# Altium schematic sheet main.SchDoc(main)
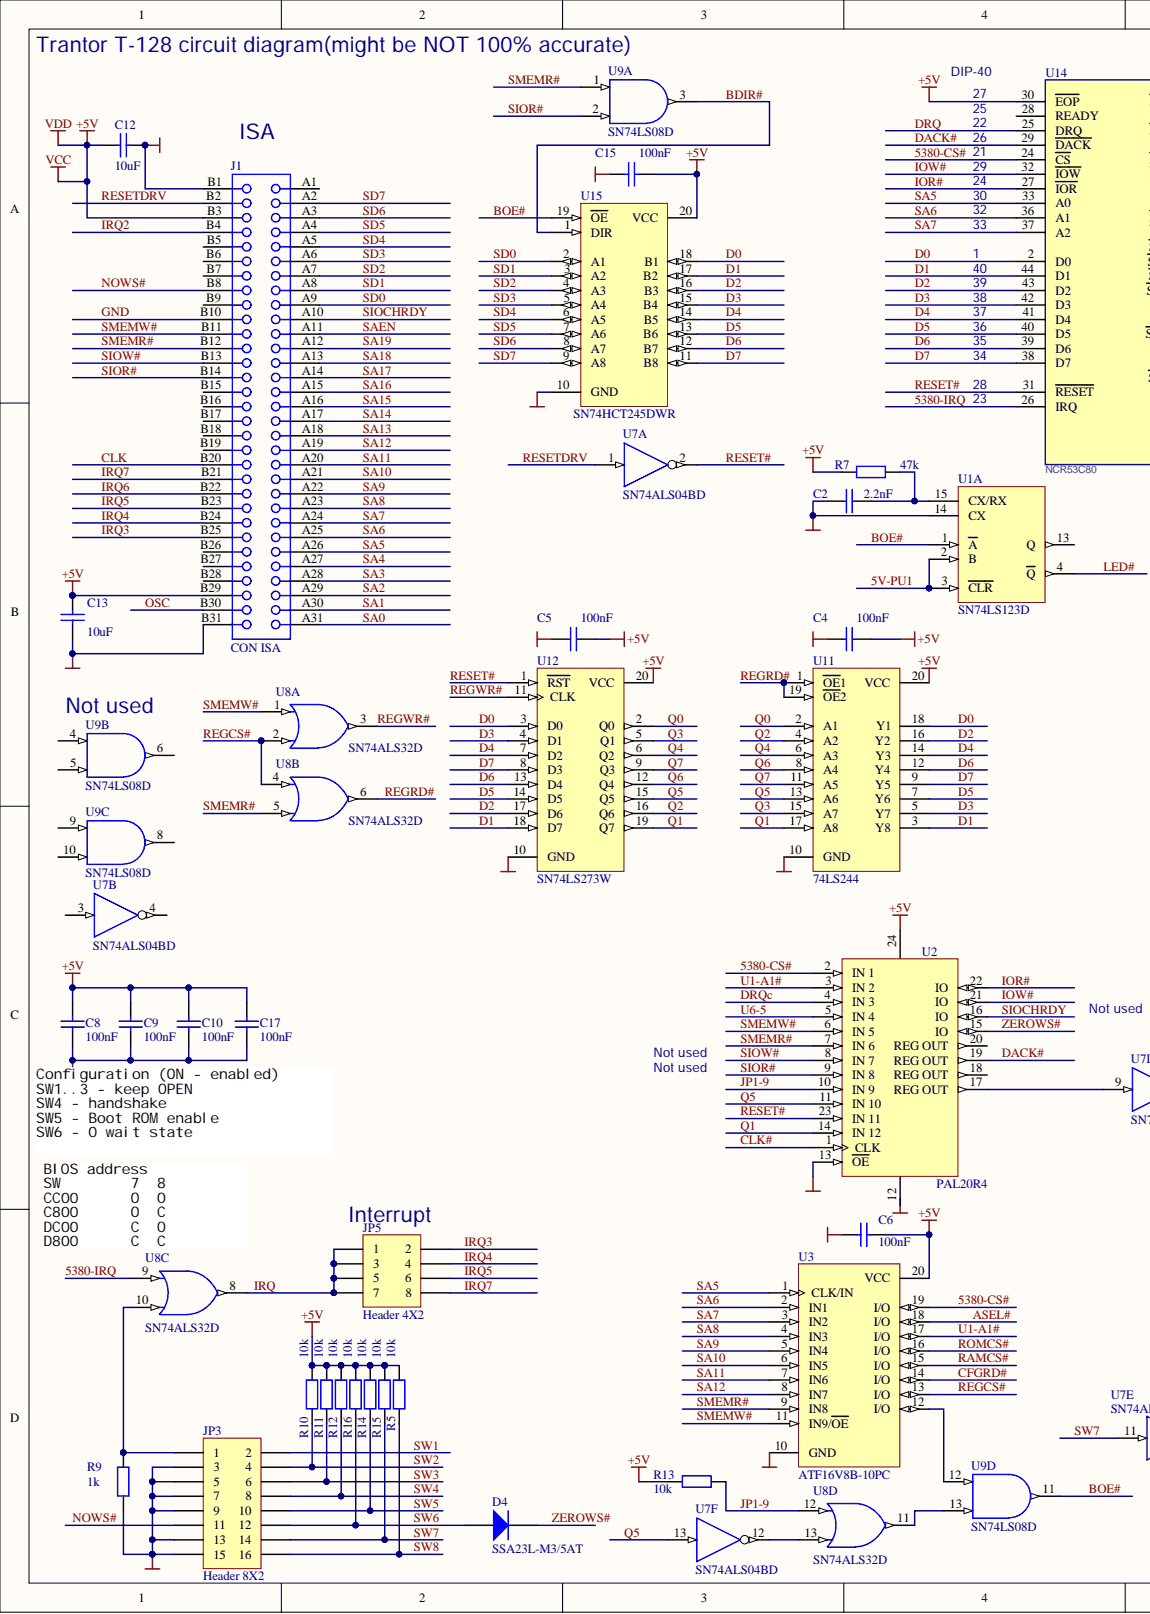
[diagram p0: main.SchDoc, left]
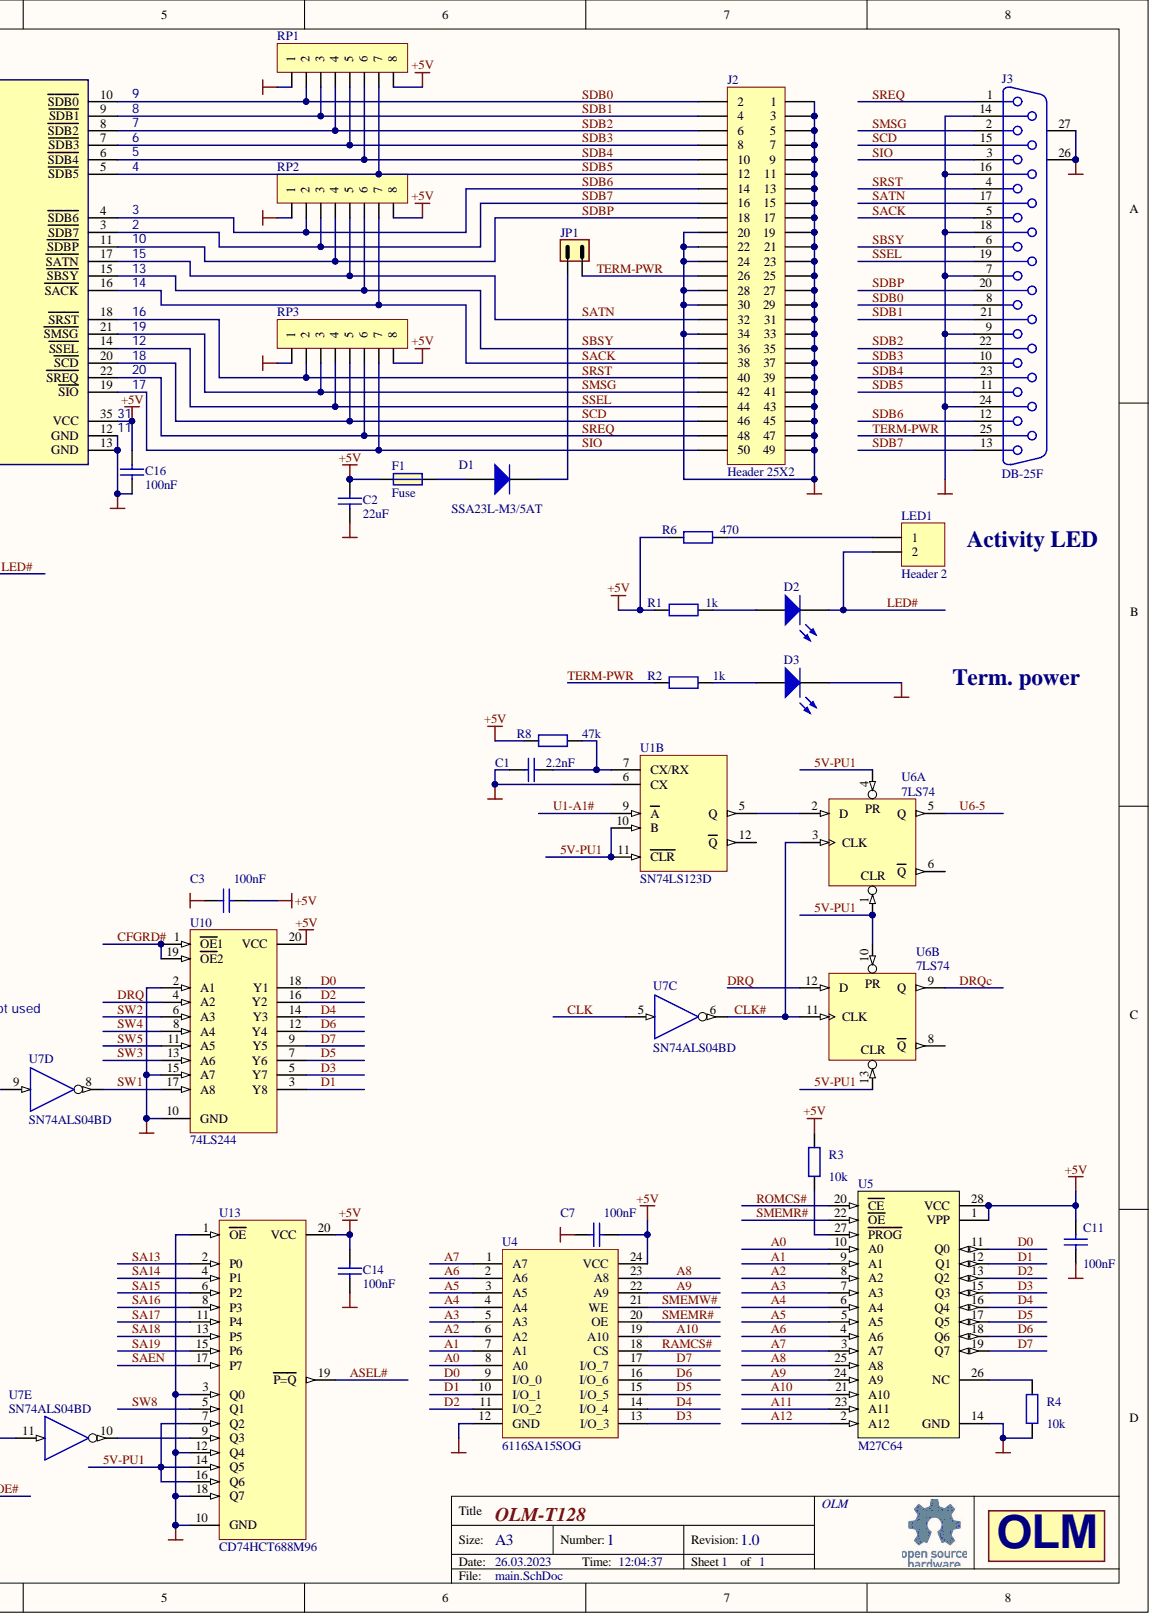
[diagram p1: main.SchDoc, right]

## Parts
A1  OSHW  [OSHW-LOGO-10MM]  (value not drawn)
C1  value 2.2nF  [SM/C_0805]  (hidden comment: Capacitor)
C2  value 22uF  [SM/C_1206]  (hidden comment: Cap)
C2  value 2.2nF  [SM/C_0805]  (hidden comment: Capacitor)
C3  value 100nF  [SM/C_0805]  (hidden comment: Capacitor)
C4  value 100nF  [SM/C_0805]  (hidden comment: Capacitor)
C5  value 100nF  [SM/C_0805]  (hidden comment: Capacitor)
C6  value 100nF  [SM/C_0805]  (hidden comment: Capacitor)
C7  value 100nF  [SM/C_0805]  (hidden comment: Capacitor)
C8  value 100nF  [SM/C_0805]  (hidden comment: Capacitor)
C9  value 100nF  [SM/C_0805]  (hidden comment: Capacitor)
C10  value 100nF  [SM/C_0805]  (hidden comment: Capacitor)
C11  value 100nF  [SM/C_0805]  (hidden comment: Capacitor)
C12  value 10uF  [SM/C_1206]  (hidden comment: Capacitor)
C13  value 10uF  [SM/C_1206]  (hidden comment: Capacitor)
C14  value 100nF  [SM/C_0805]  (hidden comment: Capacitor)
C15  value 100nF  [SM/C_0805]  (hidden comment: Capacitor)
C16  value 100nF  [SM/C_0805]  (hidden comment: Capacitor)
C17  value 100nF  [SM/C_0805]  (hidden comment: Capacitor)
D1  value SSA23L-M3/5AT  Part #: SSA23L-M3/5AT  [SM/DO214AC_21]  (hidden comment: Diode 1N4448)
D2  LED  [SM/LED_1206_Red]  (value not drawn)
D3  LED SMD  [SM/LED_1206_Green]  (value not drawn)
D4  value SSA23L-M3/5AT  Part #: SSA23L-M3/5AT  [SM/DO214AC_21]  (hidden comment: Diode 1N4448)
F1  Fuse  [Fuse_PTC_1812]
J1  CON ISA  [ISA/FINGERS_ISA_8BIT]  (no BOM)
J2  Header 25X2  [WALCON.100/VH/TM2OE/W.325/50]
J3  DB-25F  [DSUB DB-25F]
JP1  Jumper  [pls-2]  (value not drawn)
JP3  Header 8X2  [PLD-16]
JP5  Header 4X2  [PLD-8]
LED1  Header 2  [pls-2]
R1  value 1k  [SM/R_0805]  (hidden comment: Resistor)
R2  value 1k  [SM/R_0805]  (hidden comment: Resistor)
R3  value 10k  [SM/R_0805]  (hidden comment: Resistor)
R4  value 10k  [SM/R_0805]  (hidden comment: Resistor)
R5  value 10k  [SM/R_0805]  (hidden comment: Resistor)
R6  value 470  [SM/R_0805]  (hidden comment: Resistor)
R7  value 47k  [SM/R_0805]  (hidden comment: Resistor)
R8  value 47k  [SM/R_0805]  (hidden comment: Resistor)
R9  value 1k  [SM/R_0805]  (hidden comment: Resistor)
R10  value 10k  [SM/R_0805]  (hidden comment: Resistor)
R11  value 10k  [SM/R_0805]  (hidden comment: Resistor)
R12  value 10k  [SM/R_0805]  (hidden comment: Resistor)
R13  value 10k  [SM/R_0805]  (hidden comment: Resistor)
R14  value 10k  [SM/R_0805]  (hidden comment: Resistor)
R15  value 10k  [SM/R_0805]  (hidden comment: Resistor)
R16  value 10k  [SM/R_0805]  (hidden comment: Resistor)
RP1  Header 8  [SIP-8]  (value not drawn)
RP2  Header 8  [SIP-8]  (value not drawn)
RP3  Header 8  [SIP-8]  (value not drawn)
U1  SN74LS123D  [751B-05_N]  (parts A,B)
U2  PAL20R4  [DIP-24/X1.5]
U3  ATF16V8B-10PC  [PLCC20_SOCKET]
U4  6116SA15SOG  [SOG.050/24/WG.420/L.625]
U5  M27C64  [Socket DIP28]
U6  7LS74  [D014_N]  (parts A,B)
U7  SN74ALS04BD  [D014_N]  (parts A,B,C,D,E,F)
U8  SN74ALS32D  [D014_N]  (parts A,B,C,D)
U9  SN74LS08D  [751A-03_N]  (parts A,B,C,D)
U10  74LS244  [J020]
U11  74LS244  [J020]
U12  SN74LS273W  [W020]
U13  74HCT688  value CD74HCT688M96  Part #: CD74HCT688M96  [SOG.050/20/WG.420/L.500]
U14  NCR53C80  [PLCC44/SMS/.875]
U15  74HCT245  value SN74HCT245DWR  Part #: SN74HCT245DWR  [SOG.050/20/WG.420/L.500]

## Nets
+5V: C2.1 C3.1 C4.1 C5.1 C6.1 C7.1 C8.1 C9.1 C10.1 C11.1 C12.2 C13.2 C14.1 C15.1 C16.1 C17.1 F1.1 J1.B3 J1.B29 R1.2 R3.1 R5.1 R6.2 R7.2 R8.2 R10.1 R11.1 R12.1 R13.2 R14.1 R15.1 R16.1 RP1.8 RP2.8 RP3.8 U1.16 U2.24(VCC) U3.20(VCC) U4.24(VCC) U5.1(VPP) U5.28(VCC) U6.14 U7.14 U8.14 U9.14 U10.20(VCC) U11.20(VCC) U12.20(VCC) U13.20(VCC) U14.30(EOP) U14.35(VCC) U15.20(VCC)
5V-PU1: U1.2(B) U1.3(CLR) U1.10(B) U1.11(CLR) U6.1 U6.4(PR) U6.10(PR) U6.13 U13.7(Q2) U13.14(Q5) U13.16(Q6)
5380-CS#: U2.2(IN_1) U3.19(I/O) U14.24(CS)
5380-IRQ: U8.9 U14.26(IRQ)
A0: U4.8(A0) U5.10(A0)
A1: U4.7(A1) U5.9(A1)
A2: U4.6(A2) U5.8(A2)
A3: U4.5(A3) U5.7(A3)
A4: U4.4(A4) U5.6(A4)
A5: U4.3(A5) U5.5(A5)
A6: U4.2(A6) U5.4(A6)
A7: U4.1(A7) U5.3(A7)
A8: U4.23(A8) U5.25(A8)
A9: U4.22(A9) U5.24(A9)
A10: U4.19(A10) U5.21(A10)
A11: U5.23(A11)
A12: U5.2(A12)
ASEL#: U3.18(I/O) U13.19(P=Q)
BDIR#: U9.3 U15.1(DIR)
BOE#: U1.1(A) U9.11 U15.19(OE)
CFGRD#: U3.14(I/O) U10.1(OE1) U10.19(OE2)
CLK: J1.B20 U7.5
CLK#: U2.1(CLK) U6.3(CLK) U6.11(CLK) U7.6
D0: U4.9(I/O_0) U5.11(Q0) U10.18(Y1) U11.18(Y1) U12.3(D0) U14.2(D0) U15.18(B1)
D1: U4.10(I/O_1) U5.12(Q1) U10.3(Y8) U11.3(Y8) U12.18(D7) U14.44(D1) U15.17(B2)
D2: U4.11(I/O_2) U5.13(Q2) U10.16(Y2) U11.16(Y2) U12.17(D6) U14.43(D2) U15.16(B3)
D3: U4.13(I/O_3) U5.15(Q3) U10.5(Y7) U11.5(Y7) U12.4(D1) U14.42(D3) U15.15(B4)
D4: U4.14(I/O_4) U5.16(Q4) U10.14(Y3) U11.14(Y3) U12.7(D2) U14.41(D4) U15.14(B5)
D5: U4.15(I/O_5) U5.17(Q5) U10.7(Y6) U11.7(Y6) U12.14(D5) U14.40(D5) U15.13(B6)
D6: U4.16(I/O_6) U5.18(Q6) U10.12(Y4) U11.12(Y4) U12.13(D4) U14.39(D6) U15.12(B7)
D7: U4.17(I/O_7) U5.19(Q7) U10.9(Y5) U11.9(Y5) U12.8(D3) U14.38(D7) U15.11(B8)
DACK#: U2.19(REG_OUT) U14.29(DACK)
DRQ: U6.12(D) U10.4(A2) U14.25(DRQ)
DRQC: U2.4(IN_3) U6.9(Q)
GND: C1.2 C2.2 C3.2 C4.2 C5.2 C6.2 C7.2 C8.2 C9.2 C10.2 C11.2 C12.1 C13.1 C14.2 C15.2 C16.2 C17.2 D3.2 J1.B1 J1.B10 J1.B31 J2.1 J2.3 J2.5 J2.7 J2.9 J2.11 J2.13 J2.15 J2.17 J2.19 J2.20 J2.21 J2.22 J2.23 J2.24 J2.25 J2.27 J2.28 J2.29 J2.30 J2.31 J2.33 J2.34 J2.35 J2.37 J2.39 J2.41 J2.43 J2.45 J2.47 J2.49 J3.7 J3.9 J3.14 J3.16 J3.18 J3.24 J3.26 J3.27 JP3.3 JP3.5 JP3.7 JP3.9 JP3.13 JP3.15 R4.2 R9.2 RP1.1 RP2.1 RP3.1 U1.6(CX) U1.8 U1.14(CX) U2.12(GND) U2.13(OE) U3.10(GND) U4.12(GND) U5.14(GND) U6.7 U7.7 U8.7 U9.7 U10.2(A1) U10.10(GND) U10.15(A7) U11.10(GND) U12.10(GND) U13.1(OE) U13.3(Q0) U13.10(GND) U13.12(Q4) U13.18(Q7) U14.12(GND) U14.13(GND) U15.10(GND)
IOR#: U2.22(IO) U14.27(IOR)
IOW#: U2.21(IO) U14.32(IOW)
IRQ: JP5.1 JP5.3 JP5.5 JP5.7 U8.8
IRQ2: J1.B4
IRQ3: J1.B25 JP5.2
IRQ4: J1.B24 JP5.4
IRQ5: J1.B23 JP5.6
IRQ6: J1.B22
IRQ7: J1.B21 JP5.8
JP1-9: R13.1 U2.10(IN_9) U8.12
LED#: D2.2 LED1.2 U1.4(Q)
NOWS#: J1.B8 JP3.11
OSC: J1.B30
Q0: U11.2(A1) U12.2(Q0)
Q1: U2.14(IN_12) U11.17(A8) U12.19(Q7)
Q2: U11.4(A2) U12.16(Q6)
Q3: U11.15(A7) U12.5(Q1)
Q4: U11.6(A3) U12.6(Q2)
Q5: U2.11(IN_10) U7.13 U11.13(A6) U12.15(Q5)
Q6: U11.8(A4) U12.12(Q4)
Q7: U11.11(A5) U12.9(Q3)
RAMCS#: U3.15(I/O) U4.18(CS)
REGCS#: U3.13(I/O) U8.2 U8.4
REGRD#: U8.6 U11.1(OE1) U11.19(OE2)
REGWR#: U8.3 U12.11(CLK)
RESET#: U2.23(IN_11) U7.2 U12.1(RST) U14.31(RESET)
RESETDRV: J1.B2 U7.1
ROMCS#: U3.16(I/O) U5.20(CE)
SA0: J1.A31
SA1: J1.A30
SA2: J1.A29
SA3: J1.A28
SA4: J1.A27
SA5: J1.A26 U3.1(CLK/IN) U14.33(A0)
SA6: J1.A25 U3.2(IN1) U14.36(A1)
SA7: J1.A24 U3.3(IN2) U14.37(A2)
SA8: J1.A23 U3.4(IN3)
SA9: J1.A22 U3.5(IN4)
SA10: J1.A21 U3.6(IN5)
SA11: J1.A20 U3.7(IN6)
SA12: J1.A19 U3.8(IN7)
SA13: J1.A18 U13.2(P0)
SA14: J1.A17 U13.4(P1)
SA15: J1.A16 U13.6(P2)
SA16: J1.A15 U13.8(P3)
SA17: J1.A14 U13.11(P4)
SA18: J1.A13 U13.13(P5)
SA19: J1.A12 U13.15(P6)
SACK: J2.38 J3.5 RP2.7 U14.16(SACK)
SAEN: J1.A11 U13.17(P7)
SATN: J2.32 J3.17 RP2.5 U14.17(SATN)
SBSY: J2.36 J3.6 RP2.6 U14.15(SBSY)
SCD: J2.46 J3.15 RP3.5 U14.20(SCD)
SD0: J1.A9 U15.2(A1)
SD1: J1.A8 U15.3(A2)
SD2: J1.A7 U15.4(A3)
SD3: J1.A6 U15.5(A4)
SD4: J1.A5 U15.6(A5)
SD5: J1.A4 U15.7(A6)
SD6: J1.A3 U15.8(A7)
SD7: J1.A2 U15.9(A8)
SDB0: J2.2 J3.8 RP1.2 U14.10(SDB0)
SDB1: J2.4 J3.21 RP1.3 U14.9(SDB1)
SDB2: J2.6 J3.22 RP1.4 U14.8(SDB2)
SDB3: J2.8 J3.10 RP1.5 U14.7(SDB3)
SDB4: J2.10 J3.23 RP1.6 U14.6(SDB4)
SDB5: J2.12 J3.11 RP1.7 U14.5(SDB5)
SDB6: J2.14 J3.12 RP2.2 U14.4(SDB6)
SDB7: J2.16 J3.13 RP2.3 U14.3(SDB7)
SDBP: J2.18 J3.20 RP2.4 U14.11(SDBP)
SIO: J2.50 J3.3 RP3.7 U14.19(SIO)
SIOCHRDY: J1.A10 U2.16(IO)
SIOR#: J1.B14 U2.9(IN_8) U9.2
SIOW#: J1.B13 U2.8(IN_7)
SMEMR#: J1.B12 U2.7(IN_6) U3.9(IN8) U4.20(OE) U5.22(OE) U8.5 U9.1
SMEMW#: J1.B11 U2.6(IN_5) U3.11(IN9/OE) U4.21(WE) U8.1
SMSG: J2.42 J3.2 RP3.3 U14.21(SMSG)
SREQ: J2.48 J3.1 RP3.6 U14.22(SREQ)
SRST: J2.40 J3.4 RP3.2 U14.18(SRST)
SSEL: J2.44 J3.19 RP3.4 U14.14(SSEL)
SW1: JP3.2 U7.8 U10.17(A8)
SW2: JP3.4 R10.2 U10.6(A3)
SW3: JP3.6 R11.2 U10.13(A6)
SW4: JP3.8 R12.2 U10.8(A4)
SW5: JP3.10 R14.2 U10.11(A5)
SW6: D4.1 JP3.12 R16.2
SW7: JP3.14 R15.2 U7.11
SW8: JP3.16 R5.2 U13.5(Q1)
TERM-PWR: J2.26 J3.25 JP1.1 R2.2
U1-A1#: U1.9(A) U2.3(IN_2) U3.17(I/O)
U6-5: U2.5(IN_4) U6.5(Q)
ZEROWS#: D4.2 U2.15(IO)
NetC1_1: C1.1 R8.1 U1.7(CX/RX)
NetC2_1: C2.1 R7.1 U1.15(CX/RX)
NetD1_1: D1.1 F1.2
NetD1_2: D1.2 JP1.2
NetD2_1: D2.1 R1.1
NetD3_1: D3.1 R2.1
NetJP3_1: JP3.1 R9.1 U8.10
NetLED1_1: LED1.1 R6.1
NetR3_2: R3.2 U5.27(PROG)
NetR4_1: R4.1 U5.26(NC)
NetU1_5: U1.5(Q) U6.2(D)
NetU2_17: U2.17(REG_OUT) U7.9
NetU3_12: U3.12(I/O) U9.12
NetU7_10: U7.10 U13.9(Q3)
NetU7_12: U7.12 U8.13
NetU8_11: U8.11 U9.13

Not connected: J1 A1 B5 B6 B7 B9 B15 B16 B17 B18 B19 B26 B27 B28; U1 12(Q) 13(Q); U2 18(REG_OUT) 20(REG_OUT); U6 6(Q) 8(Q); U7 3 4; U9 4 5 6 8 9 10; U14 28(READY)

## Library data of the parts
C1-C11 C14-C17 = Cap ceramic: Ceramic capacitor SMD; Manufacturer: Vishay Intertech; Part #: K104K15X7RF5TH5; Part footprint: 0805; Component Kind: Standard; LCSC Part#: C2167231; Library Name: New.SCHLIB
C2 = Cap ceramic: CAP-100Nf; Component Kind: Standard; Library Name: New.SCHLIB
C12 C13 = Cap ceramic: Ceramic capacitor SMD; Manufacturer: Dersonic; Part #: CD1H106KC9IER2F100; Part footprint: 1206; Component Kind: Standard; LCSC Part#: C2761740; Library Name: New.SCHLIB
D1 D4 = Diode 1N4448: High Conductance Fast Diode; Code_JEDEC: DO-204-AH; Component Kind: Standard; ComponentLink1Description: Manufacturer Link; ComponentLink1URL: http://www.fairchildsemi.com/; ComponentLink2Description: Datasheet; ComponentLink2URL: http://www.fairchildsemi.com/ds/1N%2F1N4448.pdf; DatasheetVersion: 1997; LatestRevisionDate: 17-Jul-2002; LatestRevisionNote: Re-released for DXP Platform.; Library Name: Miscellaneous Devices.IntLib; PackageDescription: Diode, Axial; Body 3.8 x 1.9 mm (LxDia. max), Lead Dia. 0.53 mm (max); PackageReference: DO-35; Published: 8-Jun-2000; Signal Integrity: 1N4448; Simulation: 1N4448; Publisher: Altium Limited
D2 = LED0: LED SMD 1206 Red; Manufacturer: Everlight Elec; Part #: 15-21/R6C-FQ1R1B/2T; Part footprint: 1206; Value: 15-21/R6C-FQ1R1B/2T; Component Kind: Standard; LatestRevisionDate: 08-Jul-2005; LatestRevisionNote: Mech Layer 1 removed.; LCSC Part#: C93133; Library Name: Miscellaneous Devices.IntLib; PackageDescription: LED; 2 Leads; PackageReference: LED-0; Published: 8-Jun-2000; Simulation: LED0; Publisher: Altium Limited
D3 = LED0: LED SMD 1206 Green; Manufacturer: Everlight Elec; Part #: 24-21/G6C-BM1N2L/2A; Part footprint: 1206; Value: 24-21/G6C-BM1N2L/2A; Component Kind: Standard; LatestRevisionDate: 08-Jul-2005; LatestRevisionNote: Mech Layer 1 removed.; LCSC Part#: C2980185; Library Name: Miscellaneous Devices.IntLib; PackageDescription: LED; 2 Leads; PackageReference: LED-0; Published: 8-Jun-2000; Simulation: LED0; Publisher: Altium Limited
F1 = Fuse_SMD: Component Kind: Standard; Library Name: New_home.SCHLIB
J1 = CON ISA: ISA connecotr; ContactPlating: Gold Flash; DrillSize: Corresponds to nominal value.; Note: Access Keys, E6 E16 E25 F6 F16 F25 G6 G15 H6 H15 not shown.; PCB_Layout: Complies with manufacturer's recommendation.; ComponentLink1Description: Manufacturer Link; ComponentLink1URL: http://www.astron.com.tw; DatasheetDocument: Feb-1997; LatestRevisionDate: 15-Jul-2004; LatestRevisionNote: Component Replaced.; Library Name: Connectors_home.SchLib; PackageDocument: Feb-1997; PackageReference: EISA188; Published: 15-Jul-2004; Publisher: Altium Limited
J2 = Header 25X2: Header, 25-Pin, Dual row; Component Kind: Standard; LatestRevisionDate: 17-Jul-2002; LatestRevisionNote: Re-released for DXP Platform.; Library Name: Miscellaneous Connectors.IntLib; Signal Integrity: Connector; Publisher: Altium Limited
J3 = D Connector 25: Receptacle Assembly, 25 Position, Right Angle; PackageDocument: U; Positions: 25; Component Kind: Standard; DatasheetDocument: 19-Mar-1999; Gender: Receptacle; LatestRevisionDate: 17-Jul-2002; LatestRevisionNote: Re-released for DXP Platform.; Library Name: Miscellaneous Connectors.IntLib; Orientation: Right Angle; PackageReference: 787202; Published: 15-Jun-2001; Signal Integrity: Connector; Publisher: Altium Limited
JP1 = Jumper2: Jumper Wire; Manufacturer: Generic Components; Component Kind: Standard; Library Name: New_home.SCHLIB; Published: 1999; Value: Jumper
JP3 = Header 8X2: Header, 8-Pin, Dual row; Component Kind: Standard; LatestRevisionDate: 17-Jul-2002; LatestRevisionNote: Re-released for DXP Platform.; Library Name: Miscellaneous Connectors.IntLib; Signal Integrity: Connector; Publisher: Altium Limited
JP5 = Header 4X2: Header, 4-Pin, Dual row; Component Kind: Standard; LatestRevisionDate: 17-Jul-2002; LatestRevisionNote: Re-released for DXP Platform.; Library Name: Miscellaneous Connectors.IntLib; Signal Integrity: Connector; Publisher: Altium Limited
LED1 = Header 2: Header, 2-Pin; Component Kind: Standard; LatestRevisionDate: 17-Jul-2002; LatestRevisionNote: Re-released for DXP Platform.; Library Name: Miscellaneous Connectors.IntLib; Signal Integrity: Connector; Publisher: Altium Limited
R1 R2 R6-R9 = Res2: Manufacturer: Panasonic; Part #: ERJ6GEYJ102V; Part footprint: 0805; Component Kind: Standard; Description: Resistor; LatestRevisionDate: 17-Jul-2002; LatestRevisionNote: Re-released for DXP Platform.; LCSC Part#: C278655; Library Name: Miscellaneous Devices.IntLib; PackageReference: AXIAL-0.4; Published: 8-Jun-2000; Signal Integrity: Res; Simulation: RESISTOR; Publisher: Altium Limited
R3-R5 R10-R16 = Res2: Resistor SMD; Manufacturer: Panasonic; Part #: ERJ6GEYJ103V; Part footprint: 0805; Component Kind: Standard; LatestRevisionDate: 17-Jul-2002; LatestRevisionNote: Re-released for DXP Platform.; LCSC Part#: C180849; Library Name: Miscellaneous Devices.IntLib; PackageReference: AXIAL-0.4; Published: 8-Jun-2000; Signal Integrity: Res; Simulation: RESISTOR; Publisher: Altium Limited
RP1-RP3 = Header 8: Header, 8-Pin; Component Kind: Standard; LatestRevisionDate: 17-Jul-2002; LatestRevisionNote: Re-released for DXP Platform.; Library Name: New_home.SCHLIB; Signal Integrity: Connector; Publisher: Altium Limited
U1 = SN74LS123D: Retriggerable Monostable Multivibrator; Code_IPC: SOIC127P600-16; Code_JEDEC: MS-012-AC; Component Kind: Standard; ComponentLink1Description: Manufacturer Link; ComponentLink1URL: http://www.onsemi.com/; ComponentLink2Description: Datasheet; ComponentLink2URL: http://www.onsemi.com/pub/Collateral/SN74LS122-D.PDF; DatasheetVersion: Dec-1999; LatestRevisionDate: 13-Apr-2006; LatestRevisionNote: IPC-7351 Footprint Added.; Library Name: ON Semi Logic Multivibrator.IntLib; PackageDescription: 16-Pin Small Outline Integrated Circuit 1.27 mm Pitch; PackageReference: 751B-05; PackageVersion: Oct-1999; Published: 15-Apr-2002; Signal Integrity: SN74LS259N; Publisher: Altium Limited
U2 = p20r4: 7.5ns 24-Pin TTL PAL; Component Kind: Standard; LatestRevisionDate: 17-Jul-2002; LatestRevisionNote: Re-released for DXP Platform.; Published: 16-Jul-2002; Library Name: PLD Supported Devices.IntLib; Publisher: Altium Limited
U3 = ATF16V8B-10PC: ATF16V8B 5.0V Industry Standard SPLD, 20-lead PDIP, Speed Grade 10, Commercial Grade; Code_JEDEC: MS-001-AD; Component Kind: Standard; ComponentLink1Description: Manufacturer Link; ComponentLink1URL: http://www.atmel.com/products/pld/default.asp; ComponentLink2Description: Datasheet; ComponentLink2URL: http://www.atmel.com/dyn/resources/prod_documents/DOC0364.PDF; ComponentLink3Description: Atmel.com Home; ComponentLink3URL: http://www.atmel.com; DatasheetVersion: Rev. 0364I-04/01; LatestRevisionDate: 04-Mar-2005; LatestRevisionNote: Stylized 3D Model Added.; Library Name: Atmel Industry Standard SPLD.IntLib; PackageDescription: 20-lead (0.300"/7.62mm Wide) Plastic Dual Inline Package (PDIP); PackageReference: 20P3; PackageVersion: revC, Jan-2004; Published: 24-Nov-2000; Supplier 1: Digi-Key; Supplier 2: Mouser; Supplier Part Number 1: ATF16V8B-10PC-ND; Supplier Part Number 2: 556-ATF16V8B-10PC; Publisher: Altium Limited
U4 = 6116SA15SOG: 5.0V 2K x 8 Asynchronous Static RAM; Manufacturer_Name: Renesas Electronics; Geometry.Height: 2.1mm; Component Kind: Standard; Datasheet Link: https://www.renesas.com/us/en/products/memory-logic/srams/asynchronous-srams/6116-50v-2k-x-8-asynchronous-static-ram; Library Name: New_home.SCHLIB; Manufacturer_Part_Number: 6116SA15SOG; Mouser Part Number: 972-6116SA15SOG; Mouser Price/Stock: https://www.mouser.co.uk/ProductDetail/Renesas-IDT/6116SA15SOG?qs=GVScuG1d83jOy4N7V8POIA%3D%3D
U5 = M27C64A-15F1: 64 Kbit (8Kb X 8) UV EPROM and OTP EPROM; Component Kind: Standard; ComponentLink1Description: Manufacturer Link; ComponentLink1URL: http://www.st.com/; ComponentLink2Description: Datasheet; ComponentLink2URL: http://www.st.com/stonline/products/literature/ds/2388/m27c64a.pdf; DatasheetVersion: Mar-1998; LatestRevisionDate: 06-Jun-2005; LatestRevisionNote: Stylized 3D Model Added.; Library Name: ST Memory EPROM 16-512 Kbit.IntLib; PackageDescription: Ceramic Frit-seal DIP, Window; 28 Leads; Row Spacing 15.24 mm; Pitch 2.54 mm; PackageReference: FDIP28W; PCB3D: FDIP28W; Published: 24-Aug-2001; Publisher: Altium Limited
U6 = SN74AHCT74D: Dual Positive-Edge-Triggered D-Type Flip-Flop with Clear and Preset; Code_IPC: SOIC127P600-14; Component Kind: Standard; ComponentLink1Description: Manufacturer Link; ComponentLink1URL: http://www.ti.com/; ComponentLink2Description: Datasheet; ComponentLink2URL: http://www-s.ti.com/sc/ds/sn74ahct74.pdf; DatasheetVersion: Oct-1998; LatestRevisionDate: 13-Apr-2006; LatestRevisionNote: IPC-7351 Footprint Added.; Library Name: TI Logic Flip-Flop.IntLib; PackageDescription: 14-Pin Small Outline Integrated Circuit 1.27 mm Pitch; PackageReference: D014; PackageVersion: Jan-1998; PCB3D: D014; Published: 8-Jun-2000; Signal Integrity: SN74AHCT74D; Publisher: Altium Limited
U7 = SN74ALS04BD: Hex Inverter; Code_IPC: SOIC127P600-14; Component Kind: Standard; ComponentLink1Description: Manufacturer Link; ComponentLink1URL: http://www.ti.com/; ComponentLink2Description: Datasheet; ComponentLink2URL: http://www-s.ti.com/sc/ds/sn54as04.pdf; ComponentLink3Description: Packaging Specification; ComponentLink3URL: http://focus.ti.com/lit/ml/mpds177/mpds177.pdf; DatasheetVersion: Dec-1994; LatestRevisionDate: 13-Apr-2006; LatestRevisionNote: IPC-7351 Footprint Added.; Library Name: TI Logic Gate 1.IntLib; PackageDescription: 14-Pin Small Outline Integrated Circuit 1.27 mm Pitch; PackageReference: D014; PackageVersion: Jan-1998; PCB3D: D014; Published: 8-Jun-2000; Signal Integrity: SN74ALS04BD; Publisher: Altium Limited
U8 = SN74ALS32D: Quadruple 2-Input Positive-OR Gate; Code_IPC: SOIC127P600-14; Component Kind: Standard; ComponentLink1Description: Manufacturer Link; ComponentLink1URL: http://www.ti.com/; ComponentLink2Description: Datasheet; ComponentLink2URL: http://www-s.ti.com/sc/ds/sn74als32.pdf; ComponentLink3Description: Packaging Specification; ComponentLink3URL: http://focus.ti.com/lit/ml/mpds177/mpds177.pdf; DatasheetVersion: Dec-1994; LatestRevisionDate: 13-Apr-2006; LatestRevisionNote: IPC-7351 Footprint Added.; Library Name: TI Logic Gate 1.IntLib; PackageDescription: 14-Pin Small Outline Integrated Circuit 1.27 mm Pitch; PackageReference: D014; PackageVersion: Jan-1998; PCB3D: D014; Published: 8-Jun-2000; Signal Integrity: SN74ALS32D; Publisher: Altium Limited
U9 = SN74LS08D: Quad 2-Input AND Gate; ComponentAvailability: Not for New Design; Code_IPC: SOIC127P600-14; Code_JEDEC: MS-012-AB; Component Kind: Standard; ComponentLink1Description: Manufacturer Link; ComponentLink1URL: http://www.onsemi.com/; ComponentLink2Description: Datasheet; ComponentLink2URL: http://www.onsemi.com/pub/Collateral/SN74LS08-D.PDF; DatasheetVersion: Dec-1999; LatestRevisionDate: 13-Apr-2006; LatestRevisionNote: IPC-7351 Footprint Added.; Library Name: ON Semi Logic Gate.IntLib; PackageDescription: 14-Pin Small Outline Integrated Circuit 1.27 mm Pitch; PackageReference: 751A-03; Published: 15-Apr-2002; Signal Integrity: SN74LS08D; Publisher: Altium Limited
U10 U11 = SNJ54ALS244CJ: Octal Buffer and Line Driver with 3-State Outputs; Component Kind: Standard; ComponentLink1Description: Manufacturer Link; ComponentLink1URL: http://www.ti.com/; ComponentLink2Description: Datasheet; ComponentLink2URL: http://www-s.ti.com/sc/ds/sn54als244c.pdf; DatasheetVersion: Dec-1994; LatestRevisionDate: 06-Jun-2005; LatestRevisionNote: Stylized 3D Model Added.; Library Name: TI Logic Buffer Line Driver.IntLib; PackageDescription: DIP; 20 Leads; Row Spacing 7.62 mm; Pitch 2.54 mm; PackageReference: J020; PackageVersion: Jun-1999; PCB3D: J020; Published: 8-Jun-2000; Signal Integrity: SNJ54ALS244CJ; Publisher: Altium Limited
U12 = SN74LS273W: Octal D-Type Flip-Flop with Clear; Component Kind: Standard; ComponentLink1Description: Manufacturer Link; ComponentLink1URL: http://www.ti.com/; ComponentLink2Description: Datasheet; ComponentLink2URL: http://www-s.ti.com/sc/ds/sn74273.pdf; DatasheetVersion: Mar-1988; LatestRevisionDate: 04-Mar-2005; LatestRevisionNote: Stylized 3D Model Added.; Library Name: TI Logic Flip-Flop.IntLib; PackageDescription: DFP; 20 Leads; Body 13.7 x 7.6 mm (LxW max); Pitch 1.27 mm; PackageReference: W020; PackageVersion: Jan-1995; PCB3D: W020; Published: 8-Jun-2000; Signal Integrity: SN74LS273W; Simulation: SN74LS273; Publisher: Altium Limited
U13 = M74HCT688M1R: 8-Bit Equality Comparator; Manufacturer: Texas Instruments; Part footprint: SOIC-20; Code_IPC: SOIC127P1030-20; Code_JEDEC: MS-013-AC; Component Kind: Standard; ComponentLink1Description: Manufacturer Link; ComponentLink1URL: http://www.st.com/; ComponentLink2Description: Datasheet; ComponentLink2URL: http://www.st.com/stonline/products/literature/ds/2129/m74hct688.pdf; DatasheetVersion: Feb-1993; LatestRevisionDate: 13-Apr-2006; LatestRevisionNote: IPC-7351 Footprint Added.; LCSC Part#: C2649422; Library Name: ST Logic Comparator.IntLib; PackageDescription: 20-Pin Plastic Small Outline Integrated Circuit 1.27 mm Pitch; PackageReference: SO20; PackageVersion: Jul-2001; PCB3D: SO20; Published: 8-Jun-2000; Signal Integrity: M74HCT688M1R; Publisher: Altium Limited
U14 = NCR53C80_PLCC: Component Kind: Standard; Datasheet: DOCUMENTATION; Library Name: New_home.SCHLIB
U15 = MC74HCT245ADW: Octal 3-State Non-Inverting Bus Transceiver with LSTTL-Compatible Inputs; Manufacturer: Texas Instruments; Part footprint: SOIC-20; Component Kind: Standard; ComponentLink1Description: Manufacturer Link; ComponentLink1URL: http://www.onsemi.com/; ComponentLink2Description: Datasheet; ComponentLink2URL: http://www.onsemi.com/pub/Collateral/MC74HCT245A-D.PDF; DatasheetVersion: Mar-2000; LatestRevisionDate: 13-Apr-2006; LatestRevisionNote: IPC-7351 Footprint Added.; LCSC Part#: C132267; Library Name: ON Semi Interface Line Transceiver.IntLib; PackageDescription: 20-Pin Small Outline Integrated Circuit 1.27 mm Pitch; PackageReference: 751D-05; Published: 15-Apr-2002; Signal Integrity: MC74HCT245ADW; Publisher: Altium Limited
A1 = OSHW: Component Kind: Standard; Description: OSHW; Library Name: MECH_Library_home.SchLib
